# Altium schematic sheet Interfaces.SchDoc
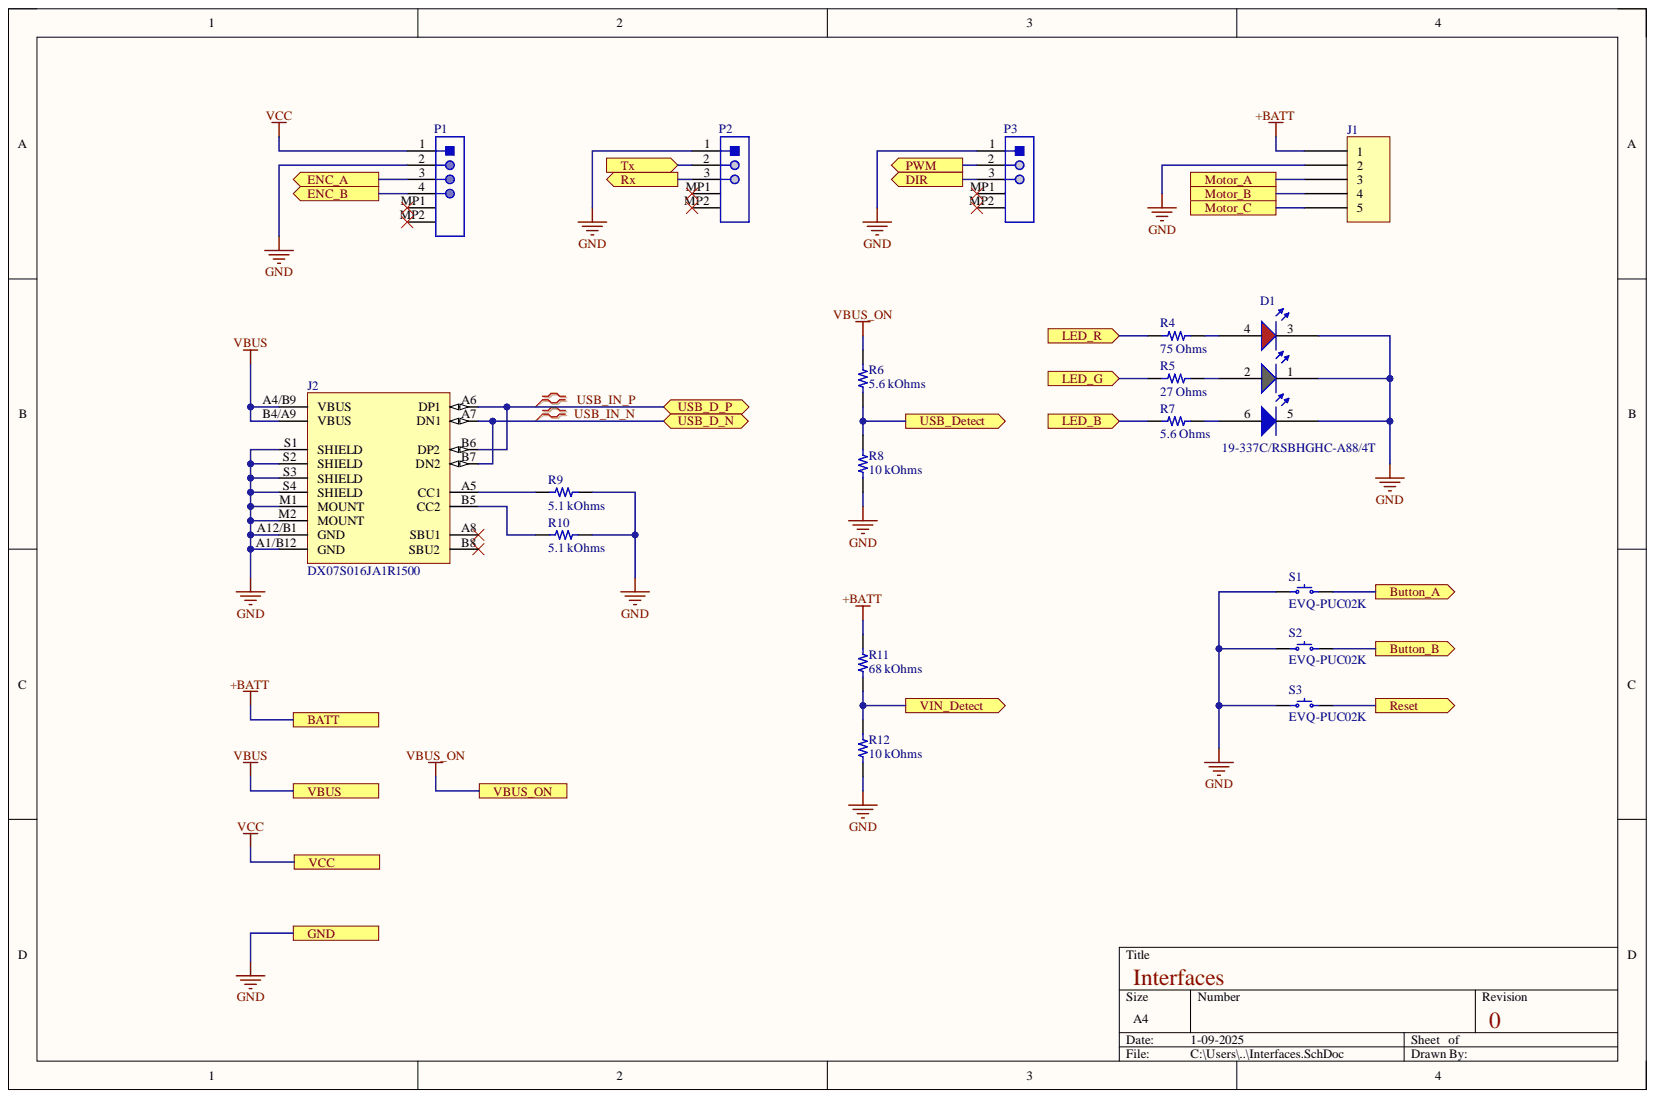
[diagram p0: Interfaces.SchDoc]

## Parts
D1  19-337C/RSBHGHC-A88/4T  [FP-19-337C-RSBHGHC-A88-4T-MFG]
J1  Power Pads 5  [Power Pads 5-Footprint-1]  (value not drawn)  (no BOM)
J2  DX07S016JA1R1500  [FP-DX07S016JA1R1500-MFG]
P1  SM04B-SRSS-TB(LF)(SN)  [SM04B-SRSS-TB(LF)(SN)-Footprint-1]  (value not drawn)
P2  SM03B-SRSS-TB(LF)(SN)  [JST-SM03B-SRSS-TB_V]  (value not drawn)
P3  SM03B-SRSS-TB(LF)(SN)  [JST-SM03B-SRSS-TB_V]  (value not drawn)
R4  75 Ohms  [YAGEO RES 0201_0603]
R5  27 Ohms  [YAGEO RES 0201_0603]
R6  5.6 kOhms  [YAGEO RES 0201_0603]
R7  5.6 Ohms  [YAGEO RES 0201_0603]
R8  10 kOhms  [YAGEO RES 0201_0603]
R9  5.1 kOhms  [YAGEO RES 0201_0603]
R10  5.1 kOhms  [YAGEO RES 0201_0603]
R11  68 kOhms  [YAGEO RES 0402_1005]
R12  10 kOhms  [YAGEO RES 0402_1005]
S1  EVQ-PUC02K  [PANASONIC EVQPUC]
S2  EVQ-PUC02K  [PANASONIC EVQPUC]
S3  EVQ-PUC02K  [PANASONIC EVQPUC]

## Ports
BATT, Button_A, Button_B, DIR, ENC_A, ENC_B, GND, LED_B, LED_G, LED_R, Motor_A, Motor_B, Motor_C, PWM, Reset, Rx, Tx, USB_D_N, USB_D_P, USB_Detect, VBUS, VBUS_ON, VCC, VIN_Detect

## Nets
+BATT: J1.1 R11.2 ; port BATT
Button_A: S1.B ; port Button_A
Button_B: S2.B ; port Button_B
DIR: P3.3 ; port DIR
ENC_A: P1.3 ; port ENC_A
ENC_B: P1.4 ; port ENC_B
GND: D1.1 D1.3 D1.5 J1.2 J2.A1/B12(GND) J2.A12/B1(GND) J2.M1(MOUNT) J2.M2(MOUNT) J2.S1(SHIELD) J2.S2(SHIELD) J2.S3(SHIELD) J2.S4(SHIELD) P1.2 P2.1 P3.1 R8.1 R9.2 R10.2 R12.1 S1.A S2.A S3.A ; port GND
LED_B: R7.1 ; port LED_B
LED_G: R5.1 ; port LED_G
LED_R: R4.1 ; port LED_R
Motor_A: J1.3 ; port Motor_A
Motor_B: J1.4 ; port Motor_B
Motor_C: J1.5 ; port Motor_C
PWM: P3.2 ; port PWM
Reset: S3.B ; port Reset
Rx: P2.3 ; port Rx
Tx: P2.2 ; port Tx
USB_Detect: R6.1 R8.2 ; port USB_Detect
USB_IN_N: J2.A7(DN1) J2.B7(DN2) ; port USB_D_N
USB_IN_P: J2.A6(DP1) J2.B6(DP2) ; port USB_D_P
VBUS: J2.A4/B9(VBUS) J2.B4/A9(VBUS) ; port VBUS
VBUS_ON: R6.2 ; port VBUS_ON
VCC: P1.1 ; port VCC
VIN_Detect: R11.1 R12.2 ; port VIN_Detect
NetD1_2: D1.2 R5.2
NetD1_4: D1.4 R4.2
NetD1_6: D1.6 R7.2
NetJ2_A5: J2.A5(CC1) R9.1
NetJ2_A8: J2.A8(SBU1)
NetJ2_B5: J2.B5(CC2) R10.1
NetJ2_B8: J2.B8(SBU2)
NetP1_MP1: P1.MP1
NetP1_MP2: P1.MP2
NetP2_MP1: P2.MP1
NetP2_MP2: P2.MP2
NetP3_MP1: P3.MP1
NetP3_MP2: P3.MP2

## Library data of the parts
D1 = CMP-00042-1: LED Tri-Color 468nm/518nm/632nm 6-Pin SMD-B T/R; Color: Blue,Green,Red; Forward Current: 20mA; Reverse Voltage: 5V; Viewing Angle: 120°; Component Kind: Standard; Height: 600um; Library Name: CSD Robocon Workspace; Max Operating Temperature: 85°C; Min Operating Temperature: -40°C
J1 = CMP-00041-1: High power direct wire to board pads - 5 Pins; Library Name: CSD Robocon Workspace
J2 = CMP-00047-1: USB Connector, 16 Contact(s), Female, Right Angle, Surface Mount Terminal, Locking, Receptacle; Current Rating: 5A; Mating Cycles: 10000; Number of Contacts: 16; Number of Ports: 1; Shielding: Shielded; USB Standard: USB 2.0; Voltage Rating: 20V; Component Kind: Standard; Connector Type: USB; Contact Material: Nickel,Gold; Contact Plating: Gold; Gender: Receptacle; Height: 3.31mm; Insulation Color: Black; Library Name: CSD Robocon Workspace; Max Operating Temperature: 85°C; Min Operating Temperature: -40°C; Mounting Technology: Surface Mount,Through Hole,Horizontal; Series: DX07; Termination: Solder
P1 = CMP-00040-1: Connector Header, Side Entry, SR Series, 4 Position, 1.00mm, 50V, Surface Mount, Tin, Natural; Contact Resistance: 40mR; Max Current Rating: 1A; Number of Contacts: 4; Pitch: 1mm; Component Kind: Standard; Connector Type: Header; Contact Finish - Mating: TIN; Contact Material: Copper Alloy; Contact Plating: Copper,Tin; Contact Shape: Square; Contact Type: Male Pin; Depth: 4.95mm; Fastening Type: Pull; Flammability Rating: UL94 V-0; Housing Color: Beige; Housing Material: Polyamide; Insulation Color: Natural; Insulation Material: Nylon; Lead Free: Lead Free; Length: 6mm; Library Name: CSD Robocon Workspace; Max Operating Temperature: 85°C; Max Voltage Rating (AC): 50V; Max Voltage Rating (DC): 50V; Min Operating Temperature: -25°C; Mounting Technology: Surface Mount; Number of Positions: 4; Number of Rows: 1; Orientation: Right Angle; Packaging: Tape and Reel; Series: SR; Termination: Solder; Voltage Rating: 50V
P2 P3 = CMP-00039-1: Connector Header, Side Entry, SR Series, 3 Position, 1.00mm, 50V, Surface Mount, Tin, Natural; Contact Resistance: 40mR; Current Rating: 1A; Gender: Male; Insulation Resistance: 100MR; Number of Contacts: 3; Pitch: 1mm; Color: Natural; Component Kind: Standard; Connector Type: Header; Contact Finish - Mating: TIN; Contact Material: Copper Alloy,Copper; Contact Plating: Tin,Lead,Copper; Contact Shape: Square; Contact Type: Male Pin; Depth: 4.95mm; Fastening Type: Pull; Flammability Rating: UL94 V-0; Housing Color: Beige; Housing Material: Polyamide; Insulation Color: Natural; Insulation Material: Nylon; Lead Free: Lead Free; Lead Pitch: 1mm; Length: 5mm; Library Name: CSD Robocon Workspace; Material: Polyamide; Max Current Rating: 1A; Max Operating Temperature: 85°C; Max Voltage Rating (AC): 50V; Min Operating Temperature: -25°C; Mounting Technology: Surface Mount; Number of Positions: 3; Number of Rows: 1; Orientation: Right Angle; Packaging: Tape and Reel; Reach SVHC Compliant: No; Series: SR; Termination: Solder; Voltage Rating: 50V; Voltage Rating (AC): 50V
R4 = RC0201FR-0775RL: RES 75 OHM 1% 1/20W 0201; Manufacturer: Yageo; Database Table Name: Resistors - Surface Mount - 0201; Power (Watts): 0.05W, 1/20W; Temperature Coefficient: ±200ppm/°C; Component Kind: Standard; ComponentLink1Description: Datasheet; ComponentLink1URL: https://www.yageo.com/upload/media/product/productsearch/datasheet/rchip/PYu-RC_Group_51_RoHS_L_10.pdf; ComponentLink2Description: DigiKey Link; ComponentLink2URL: https://www.digikey.com/en/products/detail/yageo/RC0201FR-0775RL/3202423; Composition: Thick Film; Device Package: 0201 (0603 Metric); Features: Moisture Resistant; Height - Seated (Max): 0.010" (0.26mm); LastUpdated: 25-07-2024 09:16:26; Library Name: Celestial Altium Library - altium_library.DbLib; Lifecycle Status: Active; Minimum Order: 1; Number of Terminations: 2; Operating Temperature: -55°C ~ 125°C; Package / Case: 0201 (0603 Metric); Packaging: TapeAndReel; Part Number: RC0201FR-0775RL; Part Status: Active; PartId: 1393; Price: 0.01; Resistance: 75 Ohms; Series: RC_L; Size / Dimension: 0.024" L x 0.012" W (0.60mm x 0.30mm); Supplier 1: DigiKey; Supplier Device Package: 0201; Supplier Part Number 1: YAG2289CT-ND; Tolerance: ±1%; Value: 75 Ohms
R5 = RC0201FR-0727RL: RES 27 OHM 1% 1/20W 0201; Manufacturer: Yageo; Database Table Name: Resistors - Surface Mount - 0201; Power (Watts): 0.05W, 1/20W; Temperature Coefficient: ±200ppm/°C; Component Kind: Standard; ComponentLink1Description: Datasheet; ComponentLink1URL: https://www.yageo.com/upload/media/product/productsearch/datasheet/rchip/PYu-RC_Group_51_RoHS_L_10.pdf; ComponentLink2Description: DigiKey Link; ComponentLink2URL: https://www.digikey.com/en/products/detail/yageo/RC0201FR-0727RL/5280414; Composition: Thick Film; Device Package: 0201 (0603 Metric); Features: Moisture Resistant; Height - Seated (Max): 0.010" (0.26mm); LastUpdated: 25-07-2024 09:16:22; Library Name: Celestial Altium Library - altium_library.DbLib; Lifecycle Status: Active; Minimum Order: 1; Number of Terminations: 2; Operating Temperature: -55°C ~ 125°C; Package / Case: 0201 (0603 Metric); Packaging: TapeAndReel; Part Number: RC0201FR-0727RL; Part Status: Active; PartId: 3612; Price: 0.01; Resistance: 27 Ohms; Series: RC_L; Size / Dimension: 0.024" L x 0.012" W (0.60mm x 0.30mm); Supplier 1: DigiKey; Supplier Device Package: 0201; Supplier Part Number 1: YAG2554CT-ND; Tolerance: ±1%; Value: 27 Ohms
R6 = RC0201FR-135K6L: RES 5.6K OHM 1% 1/20W 0201; Manufacturer: Yageo; Database Table Name: Resistors - Surface Mount - 0201; Power (Watts): 0.05W, 1/20W; Temperature Coefficient: ±200ppm/°C; Component Kind: Standard; ComponentLink1Description: Datasheet; ComponentLink1URL: https://www.yageo.com/upload/media/product/products/datasheet/rchip/PYu-RC_Group_51_RoHS_L_12.pdf; ComponentLink2Description: DigiKey Link; ComponentLink2URL: https://www.digikey.com/en/products/detail/yageo/RC0201FR-135K6L/17017222; Composition: Thick Film; Device Package: 0201 (0603 Metric); Features: Moisture Resistant; Height - Seated (Max): 0.010" (0.26mm); LastUpdated: 25-07-2024 09:16:18; Library Name: Celestial Altium Library - altium_library.DbLib; Lifecycle Status: Active; Minimum Order: 1; Number of Terminations: 2; Operating Temperature: -55°C ~ 125°C; Package / Case: 0201 (0603 Metric); Packaging: CutTape; Part Number: RC0201FR-135K6L; PartId: 84317; Price: 0.01; Resistance: 5.6 kOhms; Series: RC_L; Size / Dimension: 0.024" L x 0.012" W (0.60mm x 0.30mm); Supplier 1: DigiKey; Supplier Device Package: 0201; Supplier Part Number 1: 13-RC0201FR-135K6LCT-ND; Tolerance: ±1%; Value: 5.6 kOhms
R7 = RC0201FR-075R6L: RES 5.6 OHM 1% 1/20W 0201; Manufacturer: Yageo; Database Table Name: Resistors - Surface Mount - 0201; Power (Watts): 0.05W, 1/20W; Temperature Coefficient: -100/ +350ppm/°C; Component Kind: Standard; ComponentLink1Description: Datasheet; ComponentLink1URL: https://www.yageo.com/upload/media/product/productsearch/datasheet/rchip/PYu-RC_Group_51_RoHS_L_11.pdf; ComponentLink2Description: DigiKey Link; ComponentLink2URL: https://www.digikey.com/en/products/detail/yageo/RC0201FR-075R6L/5917115; Composition: Thick Film; Device Package: 0201 (0603 Metric); Features: Moisture Resistant; Height - Seated (Max): 0.010" (0.26mm); LastUpdated: 25-07-2024 09:16:21; Library Name: Celestial Altium Library - altium_library.DbLib; Lifecycle Status: Active; Minimum Order: 1; Number of Terminations: 2; Operating Temperature: -55°C ~ 125°C; Package / Case: 0201 (0603 Metric); Packaging: TapeAndReel; Part Number: RC0201FR-075R6L; Part Status: Active; PartId: 64537; Price: 0.01; Resistance: 5.6 Ohms; Series: RC_L; Size / Dimension: 0.024" L x 0.012" W (0.60mm x 0.30mm); Supplier 1: DigiKey; Supplier Device Package: 0201; Supplier Part Number 1: 13-RC0201FR-075R6LCT-ND; Tolerance: ±1%; Value: 5.6 Ohms
R8 = RC0201FR-0710KL: RES 10K OHM 1% 1/20W 0201; Manufacturer: Yageo; Database Table Name: Resistors - Surface Mount - 0201; Power (Watts): 0.05W, 1/20W; Temperature Coefficient: ±200ppm/°C; Component Kind: Standard; ComponentLink1Description: Datasheet; ComponentLink1URL: https://www.yageo.com/upload/media/product/productsearch/datasheet/rchip/PYu-RC_Group_51_RoHS_L_10.pdf; ComponentLink2Description: DigiKey Link; ComponentLink2URL: https://www.digikey.com/en/products/detail/yageo/RC0201FR-0710KL/1948870; Composition: Thick Film; Device Package: 0201 (0603 Metric); Features: Moisture Resistant; Height - Seated (Max): 0.010" (0.26mm); LastUpdated: 25-07-2024 09:16:23; Library Name: Celestial Altium Library - altium_library.DbLib; Lifecycle Status: Active; Minimum Order: 1; Number of Terminations: 2; Operating Temperature: -55°C ~ 125°C; Package / Case: 0201 (0603 Metric); Packaging: TapeAndReel; Part Number: RC0201FR-0710KL; Part Status: Active; PartId: 2281; Price: 0.01; Resistance: 10 kOhms; Series: RC_L; Size / Dimension: 0.024" L x 0.012" W (0.60mm x 0.30mm); Supplier 1: DigiKey; Supplier Device Package: 0201; Supplier Part Number 1: 311-10.0KMCT-ND; Tolerance: ±1%; Value: 10 kOhms
R9 R10 = RC0201FR-075K1L: RES 5.1K OHM 1% 1/20W 0201; Manufacturer: Yageo; Database Table Name: Resistors - Surface Mount - 0201; Power (Watts): 0.05W, 1/20W; Temperature Coefficient: ±200ppm/°C; Component Kind: Standard; ComponentLink1Description: Datasheet; ComponentLink1URL: https://www.yageo.com/upload/media/product/productsearch/datasheet/rchip/PYu-RC_Group_51_RoHS_L_10.pdf; ComponentLink2Description: DigiKey Link; ComponentLink2URL: https://www.digikey.com/en/products/detail/yageo/RC0201FR-075K1L/5280601; Composition: Thick Film; Device Package: 0201 (0603 Metric); Features: Moisture Resistant; Height - Seated (Max): 0.010" (0.26mm); LastUpdated: 25-07-2024 09:16:26; Library Name: Celestial Altium Library - altium_library.DbLib; Lifecycle Status: Active; Minimum Order: 1; Number of Terminations: 2; Operating Temperature: -55°C ~ 125°C; Package / Case: 0201 (0603 Metric); Packaging: TapeAndReel; Part Number: RC0201FR-075K1L; Part Status: Active; PartId: 1398; Price: 0.01; Resistance: 5.1 kOhms; Series: RC_L; Size / Dimension: 0.024" L x 0.012" W (0.60mm x 0.30mm); Supplier 1: DigiKey; Supplier Device Package: 0201; Supplier Part Number 1: YAG2741CT-ND; Tolerance: ±1%; Value: 5.1 kOhms
R11 = RC0402FR-0768KL: RES 68K OHM 1% 1/16W 0402; Manufacturer: Yageo; Database Table Name: Resistors - Surface Mount - 0402; Power (Watts): 0.063W, 1/16W; Temperature Coefficient: ±100ppm/°C; Component Kind: Standard; ComponentLink1Description: Datasheet; ComponentLink1URL: https://www.yageo.com/upload/media/product/productsearch/datasheet/rchip/PYu-RC_Group_51_RoHS_L_10.pdf; ComponentLink2Description: DigiKey Link; ComponentLink2URL: https://www.digikey.com/en/products/detail/yageo/RC0402FR-0768KL/726651; Composition: Thick Film; Device Package: 0402 (1005 Metric); Features: Moisture Resistant; Height - Seated (Max): 0.016" (0.40mm); LastUpdated: 25-07-2024 09:18:19; Library Name: Celestial Altium Library - altium_library.DbLib; Lifecycle Status: Active; Minimum Order: 1; Number of Terminations: 2; Operating Temperature: -55°C ~ 155°C; Package / Case: 0402 (1005 Metric); Packaging: CutTape; Part Number: RC0402FR-0768KL; Part Status: Active; PartId: 2524; Price: 0.01; Resistance: 68 kOhms; Series: RC_L; Size / Dimension: 0.039" L x 0.020" W (1.00mm x 0.50mm); Supplier 1: DigiKey; Supplier Device Package: 0402; Supplier Part Number 1: 311-68.0KLRCT-ND; Tolerance: ±1%; Value: 68 kOhms
R12 = RC0402FR-0710KL: RES 10K OHM 1% 1/16W 0402; Manufacturer: Yageo; Database Table Name: Resistors - Surface Mount - 0402; Power (Watts): 0.063W, 1/16W; Temperature Coefficient: ±100ppm/°C; Component Kind: Standard; ComponentLink1Description: Datasheet; ComponentLink1URL: https://www.yageo.com/upload/media/product/productsearch/datasheet/rchip/PYu-RC_Group_51_RoHS_L_10.pdf; ComponentLink2Description: DigiKey Link; ComponentLink2URL: https://www.digikey.com/en/products/detail/yageo/RC0402FR-0710KL/726523; Composition: Thick Film; Device Package: 0402 (1005 Metric); Features: Moisture Resistant; Height - Seated (Max): 0.016" (0.40mm); LastUpdated: 25-07-2024 09:18:11; Library Name: Celestial Altium Library - altium_library.DbLib; Lifecycle Status: Active; Minimum Order: 1; Number of Terminations: 2; Operating Temperature: -55°C ~ 155°C; Package / Case: 0402 (1005 Metric); Packaging: CutTape; Part Number: RC0402FR-0710KL; Part Status: Active; PartId: 17593; Price: 0.01; Resistance: 10 kOhms; Series: RC_L; Size / Dimension: 0.039" L x 0.020" W (1.00mm x 0.50mm); Supplier 1: DigiKey; Supplier Device Package: 0402; Supplier Part Number 1: 311-10.0KLRCT-ND; Tolerance: ±1%; Value: 10 kOhms
S1-S3 = EVQ-PUC02K: SWITCH TACTILE SPST-NO 0.05A 12V; Manufacturer: Panasonic Electronic Components; Actuator Orientation: Side Actuated; Actuator Type: Rectangular Button; Contact Rating @ Voltage: 0.05A @ 12VDC; Database Table Name: Switches - Tactile; Illumination: Non-Illuminated; Operating Force: 220gf; Outline: 6.40mm x 3.50mm; Switch Function: Off-Mom; Circuit: SPST-NO; Component Kind: Standard; ComponentLink1Description: Datasheet; ComponentLink1URL: https://www3.panasonic.biz/ac/e_download/control/switch/light-touch/catalog/sw_lt_eng_smalls_side.pdf?f_cd=402910&via=ok; ComponentLink2Description: DigiKey Link; ComponentLink2URL: https://www.digikey.com/en/products/detail/panasonic-electronic-components/EVQ-PUC02K/286336; Features: Board Guide; LastUpdated: 26-08-2024 15:35:09; Library Name: Celestial Altium Library - altium_library.DbLib; Lifecycle Status: Active; Minimum Order: 1; Mounting Type: Surface Mount, Right Angle; Operating Temperature: -20°C ~ 70°C; Packaging: TapeAndReel; Part Number: EVQ-PUC02K; Part Status: Active; Price: 0.30; Series: EVQPU; Supplier 1: DigiKey; Supplier Part Number 1: P10849SCT-ND; Termination Style: Gull Wing
